# Altium schematic sheet MAIN-SCH.SchDoc
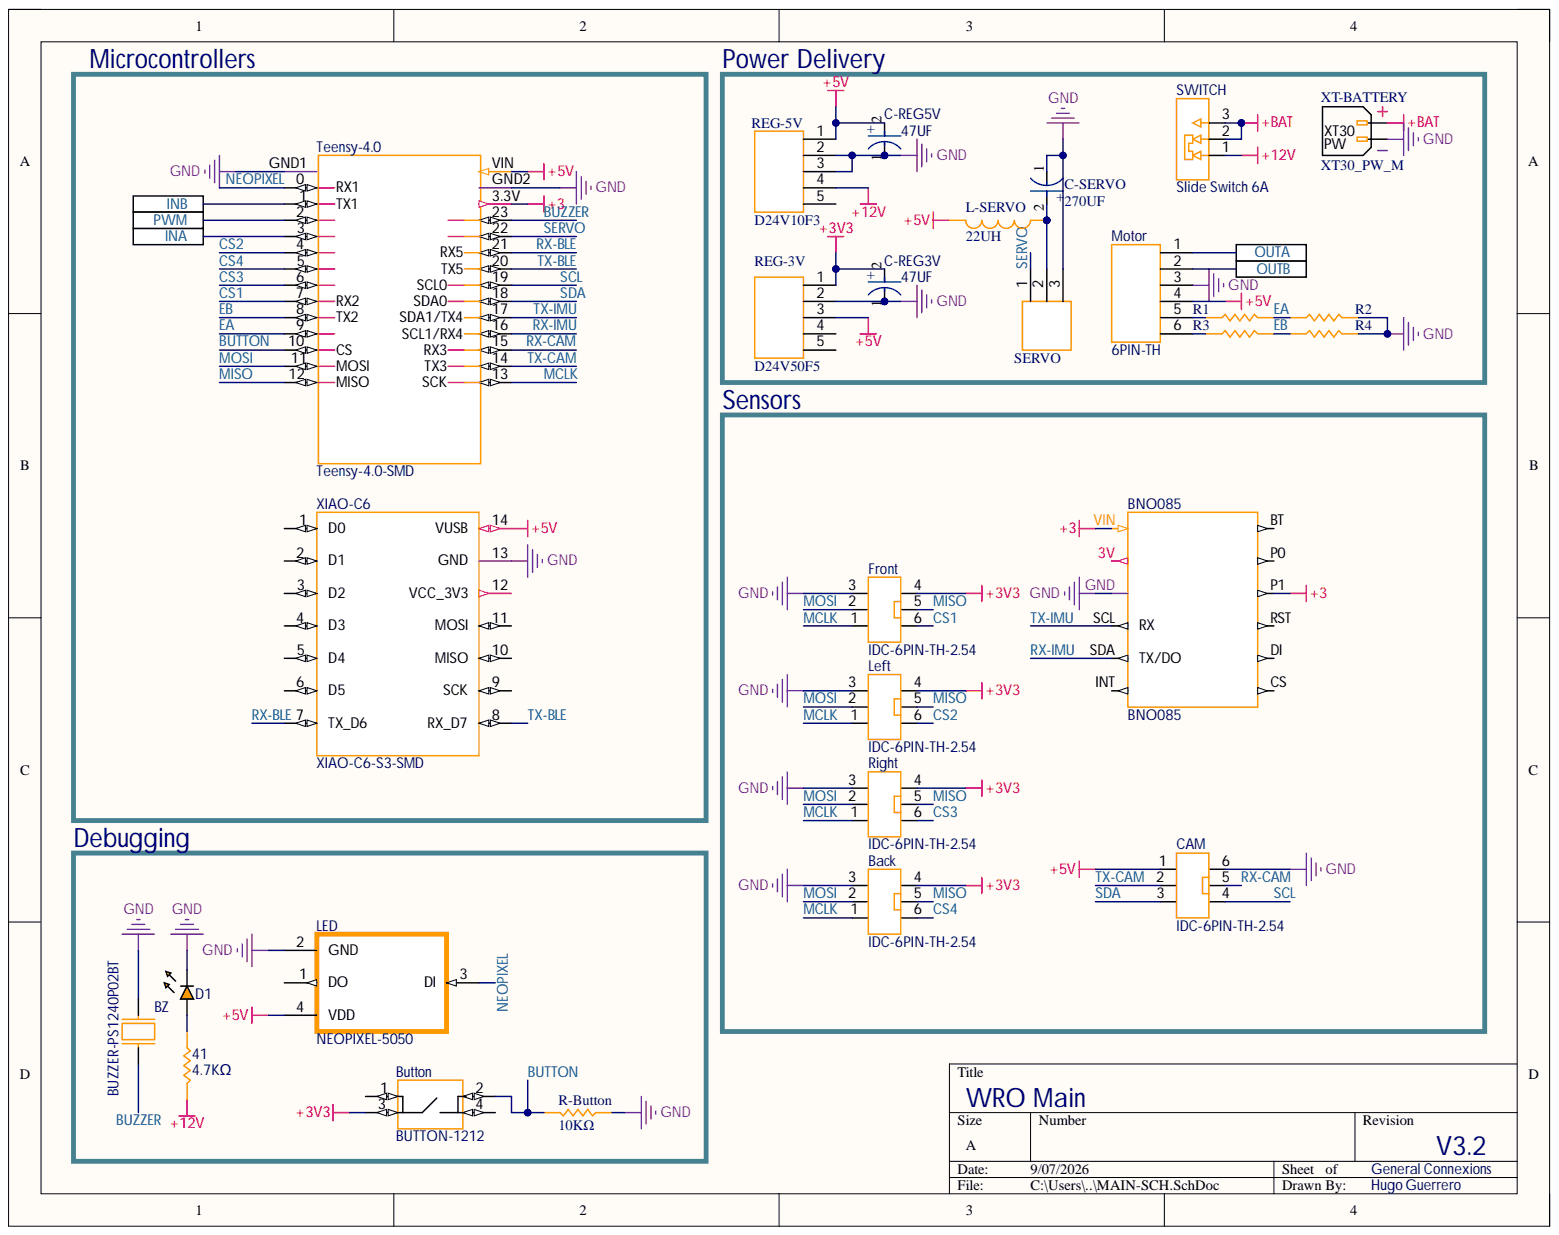
[diagram p0: MAIN-SCH.SchDoc]

## Parts
41  4.7KΩ  [RES_0603]
Back  IDC-6PIN-TH-2.54  [IDC-6PIN-TH-2.54]
BNO085  BNO085  [BNO085]
Button  BUTTON-1212  [BUTTON]
BZ  BUZZER-PS1240P02BT  [BUZZER]
C-REG3V  47UF  [FP-FTD8-MFG]
C-REG5V  47UF  [FP-FTD8-MFG]
C-SERVO  270UF  [FP-FTD8-MFG]
CAM  IDC-6PIN-TH-2.54  [IDC-6PIN-TH-2.54]
D1  LED_0603  [LED_0603]  (value not drawn)
D24V10F3  REG-5V  [5PIN-TH]
D24V50F5  REG-3V  [5PIN-TH]
Front  IDC-6PIN-TH-2.54  [IDC-6PIN-TH-2.54]
L-SERVO  22UH  [WRO-INDUCTOR]
LED  NEOPIXEL-5050  [NEOPIXEL-5050]
Left  IDC-6PIN-TH-2.54  [IDC-6PIN-TH-2.54]
Motor  6PIN-TH  [6PIN-TH]
R1  10KΩ  [RES_0603]  (value not drawn)
R2  10KΩ  [RES_0603]  (value not drawn)
R3  10KΩ  [RES_0603]  (value not drawn)
R4  10KΩ  [RES_0603]  (value not drawn)
R-Button  10KΩ  [RES_0603]
Right  IDC-6PIN-TH-2.54  [IDC-6PIN-TH-2.54]
SERVO  3PIN  [3PIN]  (value not drawn)
SWITCH  Slide Switch 6A  [Slide Switch 6A]
Teensy-4.0  Teensy-4.0-SMD  [Teensy-4.0-SMD]
XIAO-C6  XIAO-C6-S3-SMD  [XIAO-C6-S3-SMD]
XT-BATTERY  XT30_PW_M  [XT30_PW_M]

## Ports
INA, INB, OUTA, OUTB, PWM

## Nets
+3: BNO085.P1 BNO085.VIN Teensy-4.0.3.3V
+3V3: Back.4 Button.3 C-REG3V.2 D24V50F5.1 Front.4 Left.4 Right.4
+5V: C-REG5V.2 CAM.1 D24V10F3.1 D24V50F5.3 L-SERVO.2 LED.4(VDD) Motor.4 Teensy-4.0.VIN XIAO-C6.14(VUSB)
+12V: 41.1 D24V10F3.4 SWITCH.1
+BAT: SWITCH.2 SWITCH.3 XT-BATTERY.P
GND: Back.3 BNO085.GND BZ.2 C-REG3V.1 C-REG5V.1 C-SERVO.1 CAM.6 D1.C D24V10F3.2 D24V10F3.3 D24V50F5.2 Front.3 LED.2(GND) Left.3 Motor.3 R2.1 R4.1 R-Button.2 Right.3 SERVO.3 Teensy-4.0.GND1 Teensy-4.0.GND2 XIAO-C6.13(GND) XT-BATTERY.N
BUTTON: Button.2 R-Button.1 Teensy-4.0.10(CS)
BUZZER: BZ.1 Teensy-4.0.23
CS1: Front.6 Teensy-4.0.7(RX2)
CS2: Left.6 Teensy-4.0.4
CS3: Right.6 Teensy-4.0.6
CS4: Back.6 Teensy-4.0.5
EA: R1.1 R2.2 Teensy-4.0.9
EB: R3.1 R4.2 Teensy-4.0.8(TX2)
INA: Teensy-4.0.3 ; port INA
INB: Teensy-4.0.1(TX1) ; port INB
MCLK: Back.1 Front.1 Left.1 Right.1 Teensy-4.0.13
MISO: Back.5 Front.5 Left.5 Right.5 Teensy-4.0.12(MISO)
MOSI: Back.2 Front.2 Left.2 Right.2 Teensy-4.0.11(MOSI)
NEOPIXEL: LED.3(DI) Teensy-4.0.0(RX1)
OUTA: Motor.1 ; port OUTA
OUTB: Motor.2 ; port OUTB
PWM: Teensy-4.0.2 ; port PWM
RX-BLE: Teensy-4.0.21(RX5) XIAO-C6.7(TX_D6)
RX-CAM: CAM.5 Teensy-4.0.15
RX-IMU: BNO085.SDA(TX/DO) Teensy-4.0.16(SCL1/RX4)
SCL: CAM.4 Teensy-4.0.19
SDA: CAM.3 Teensy-4.0.18
SERVO: SERVO.1 Teensy-4.0.22
TX-BLE: Teensy-4.0.20(TX5) XIAO-C6.8(RX_D7)
TX-CAM: CAM.2 Teensy-4.0.14
TX-IMU: BNO085.SCL(RX) Teensy-4.0.17(SDA1/TX4)
Net41_2: 41.2 D1.A
NetC-SERVO_2: C-SERVO.2 L-SERVO.1 SERVO.2
NetMotor_5: Motor.5 R1.2
NetMotor_6: Motor.6 R3.2

Not connected: BNO085 3V BT CS DI INT P0 RST; Button 1 4; D24V10F3 5; D24V50F5 4 5; LED 1(DO); XIAO-C6 1(D0) 2(D1) 3(D2) 4(D3) 5(D4) 6(D5) 9(SCK) 10(MISO) 11(MOSI) 12(VCC_3V3)

## Library data of the parts
41 R1-R4 R-Button = RES_0603: Resistor SMD 0603 generic; Component Kind: Standard
  41: Library Name: GenericRC.SchLib
  R1-R4 R-Button: Library Name: RC.IntLib
Button = BUTTON: Component Kind: Standard; Library Name: RC.IntLib
C-REG3V C-REG5V C-SERVO = CMP-05427-000088-1: CAP ALUM 220UF 20% 25V SMD; Dielectric Material: Aluminium; ESR (Equivalent Series Resistance): 160mR; Lead Diameter: 0.65mm; Leakage Current: 55uA; Life (Hours): 2000Hour; Ripple Current: 600mA; Capacitance: 220uF; Case/Package: Radial; Component Kind: Standard; Construction: Cylindrical; Contact Plating: Tin; Diameter: 6.3mm; Height: 7.7mm; Height - Seated (Max): 0.315inch; Lead Free: Lead Free; Lead Pitch: 1.8mm; Length: 7.7mm; Library Name: Altium Content Vault; Max Operating Temperature: 105°C; Min Operating Temperature: -55°C; Mount: Surface Mount; Number of Pins: 8; Package Quantity: 1; Packaging: Tape and Reel; Radiation Hardening: No; REACH SVHC: No SVHC; Ripple Current (AC): 600mA; RoHS Compliant: Yes; Series: FT; Termination: SMD/SMT; Tolerance: 20%; Voltage: 25V; Voltage Rating: 25V; Voltage Rating (DC): 25V
D24V10F3 D24V50F5 = 5PIN-TH: 2.54 Header; Component Kind: Standard; Library Name: RC.IntLib
L-SERVO = WRO-INDUCTOR: Voltage Boost Inductor; Component Kind: Standard; Library Name: RC.IntLib
SERVO = 3PIN: 2.54 Header; Component Kind: Standard; Library Name: RC.IntLib
Back CAM Front Left Right = IDC-6PIN-TH-2.54: Component Kind: Standard; Library Name: RC.IntLib
BNO085 = BNO085: Component Kind: Standard; Library Name: RC.IntLib
BZ = BUZZER-PS1240P02BT: Component Kind: Standard; Library Name: RC.IntLib
D1 = LED_0603: Component Kind: Standard; Library Name: GenericRC.SchLib
LED = NEOPIXEL-5050: Component Kind: Standard; Library Name: RC.IntLib
Motor = 6PIN-TH: Component Kind: Standard; Library Name: RC.IntLib
SWITCH = Slide Switch 6A: Component Kind: Standard; Library Name: RC.IntLib
Teensy-4.0 = Teensy-4.0-SMD: Component Kind: Standard; Library Name: RC.IntLib
XIAO-C6 = XIAO-C6-S3-SMD: Component Kind: Standard; Library Name: RC.IntLib
XT-BATTERY = XT30_PW_M: Component Kind: Standard; Library Name: RC.IntLib
